# Altium schematic sheet 10 - POWER, RESET.SchDoc
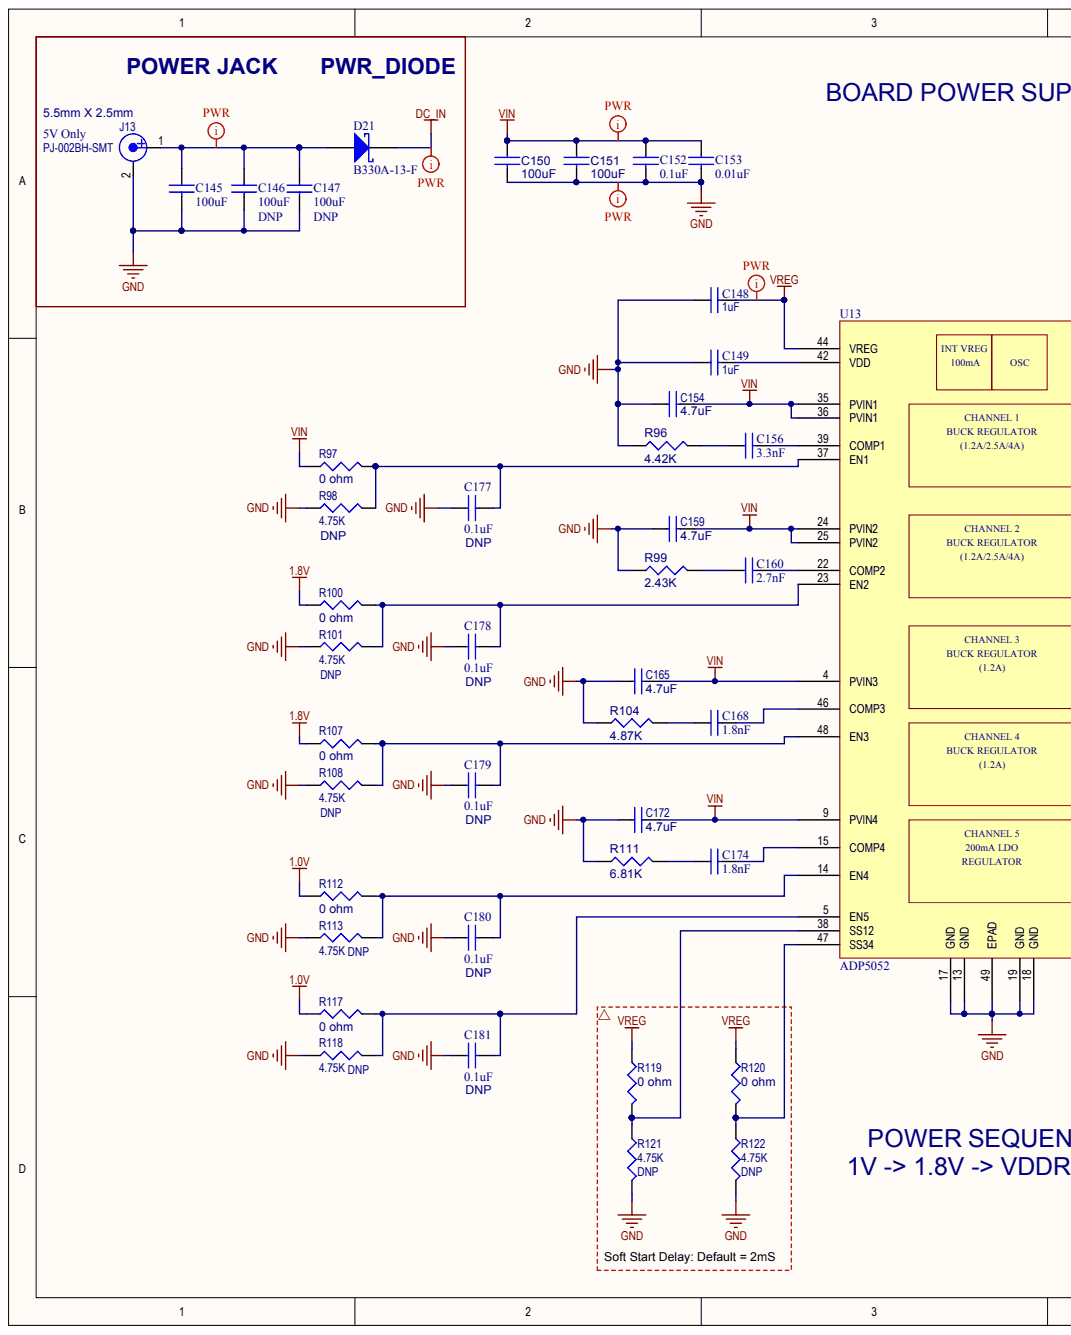
[diagram p0: 10 - POWER, RESET.SchDoc, left]
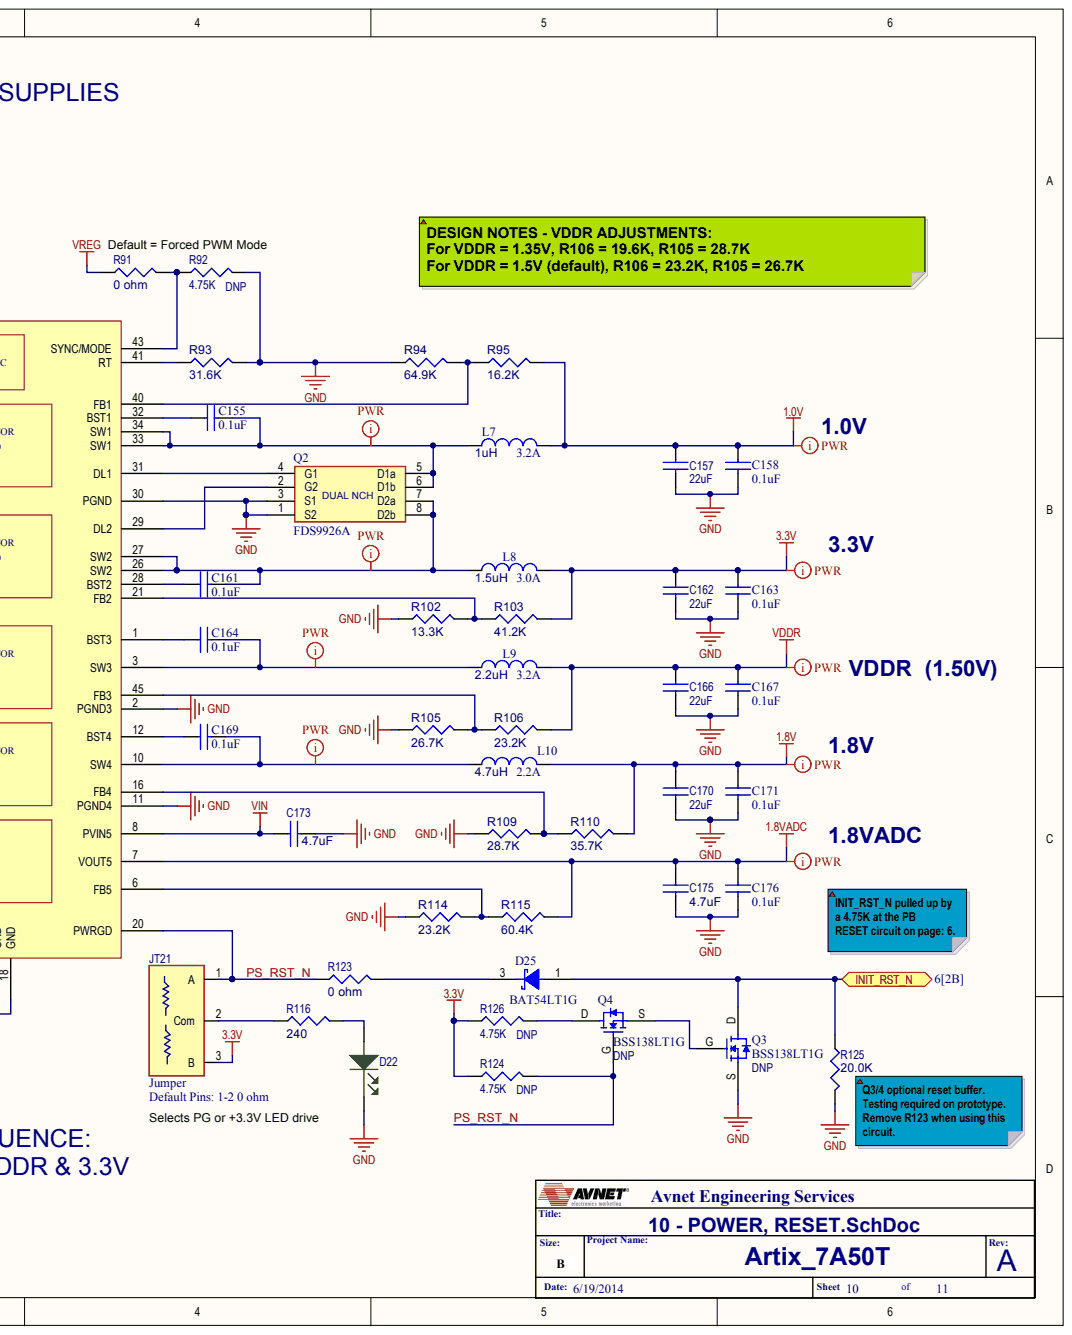
[diagram p1: 10 - POWER, RESET.SchDoc, right]

## Parts
C145  value 100uF  (hidden comment: PC074)
C146  value 100uF  (hidden comment: PC074)
C147  value 100uF  (hidden comment: PC074)
C148  value 1uF  (hidden comment: CAP_GEN_1uF_0402)
C149  value 1uF  (hidden comment: CAP_GEN_1uF_0402)
C150  100uF
C151  100uF
C152  value 0.1uF  (hidden comment: PC522)
C153  value 0.01uF  (hidden comment: PC003)
C154  value 4.7uF  (hidden comment: 4.8uF)
C155  value 0.1uF  (hidden comment: PC522)
C156  value 3.3nF
C157  value 22uF  (hidden comment: GRM219R61A226MEA0D)
C158  value 0.1uF  (hidden comment: PC522)
C159  value 4.7uF  (hidden comment: 4.8uF)
C160  value 2.7nF
C161  value 0.1uF  (hidden comment: PC522)
C162  value 22uF  (hidden comment: GRM219R61A226MEA0D)
C163  value 0.1uF  (hidden comment: PC522)
C164  value 0.1uF  (hidden comment: PC522)
C165  value 4.7uF  (hidden comment: 4.8uF)
C166  value 22uF  (hidden comment: GRM219R61A226MEA0D)
C167  value 0.1uF  (hidden comment: PC522)
C168  value 1.8nF
C169  value 0.1uF  (hidden comment: PC522)
C170  value 22uF  (hidden comment: GRM219R61A226MEA0D)
C171  value 0.1uF  (hidden comment: PC522)
C172  value 4.7uF  (hidden comment: 4.8uF)
C173  value 4.7uF  (hidden comment: 4.8uF)
C174  value 1.8nF
C175  value 4.7uF  (hidden comment: 4.8uF)
C176  value 0.1uF  (hidden comment: PC522)
C177  value 0.1uF  (hidden comment: PC522)
C178  value 0.1uF  (hidden comment: PC522)
C179  value 0.1uF  (hidden comment: PC522)
C180  value 0.1uF  (hidden comment: PC522)
C181  value 0.1uF  (hidden comment: PC522)
D21  Device: B330A-13-F  (hidden comment: DD031)
D22  DL018  (value not drawn)
D25  BAT54LT1G
J13  Device: PJ-002BH-SMT  (hidden comment: EC068)
JT21  value 0 ohm  Device: Jumper  Default Pins: 1-2 0 ohm
L7  value 1uH  I: 3.2A  (hidden comment: ME3220-102MLB)
L8  value 1.5uH  I: 3.0A  (hidden comment: ME3220-102MLB)
L9  value 2.2uH  I: 3.2A  (hidden comment: ME3220-102MLB)
L10  value 4.7uH  I: 2.2A  (hidden comment: ME3220-102MLB)
Q2  MFGPN: FDS9926A  (hidden comment: FDS9926A DUAL MOSFET)
Q3  BSS138  MFGPN: BSS138LT1G
Q4  BSS138  MFGPN: BSS138LT1G
R91  0 ohm
R92  value 4.75K  (hidden comment: 0402)
R93  value 31.6K  (hidden comment: 0402 RES, 1%, Generic)
R94  value 64.9K  (hidden comment: 0402 RES, 1%, Generic)
R95  value 16.2K  (hidden comment: 0402 RES, 1%, Generic)
R96  value 4.42K  (hidden comment: 0402 RES, 1%, Generic)
R97  0 ohm
R98  value 4.75K  (hidden comment: 0402)
R99  value 2.43K  (hidden comment: 0402 RES, 1%, Generic)
R100  0 ohm
R101  value 4.75K  (hidden comment: 0402)
R102  value 13.3K  (hidden comment: 0402 RES, 1%, Generic)
R103  value 41.2K  (hidden comment: 0402 RES, 1%, Generic)
R104  value 4.87K  (hidden comment: 0402 RES, 1%, Generic)
R105  value 26.7K  (hidden comment: 0402 RES, 1%, Generic)
R106  value 23.2K  (hidden comment: 0402 RES, 1%, Generic)
R107  0 ohm
R108  value 4.75K  (hidden comment: 0402)
R109  value 28.7K  (hidden comment: 0402 RES, 1%, Generic)
R110  value 35.7K  (hidden comment: 0402 RES, 1%, Generic)
R111  value 6.81K  (hidden comment: 0402 RES, 1%, Generic)
R112  0 ohm
R113  value 4.75K  (hidden comment: 0402)
R114  value 23.2K  (hidden comment: 0402 RES, 1%, Generic)
R115  value 60.4K  (hidden comment: 0402 RES, 1%, Generic)
R116  240
R117  0 ohm
R118  value 4.75K  (hidden comment: 0402)
R119  0 ohm
R120  0 ohm
R121  value 4.75K  (hidden comment: 0402)
R122  value 4.75K  (hidden comment: 0402)
R123  0 ohm
R124  value 4.75K  (hidden comment: 0402)
R125  20.0K
R126  value 4.75K  (hidden comment: 0402)
U13  ADP5052

## Ports
INIT_RST_N

## Nets
1.0V: C157.1 C158.1 L7.2 R95.2 R112.1 R117.1
1.8V: C170.1 C171.1 L10.2 R100.1 R107.1 R110.2
1.8VADC: C175.1 C176.1 R115.2 U13.7(VOUT5)
3.3V: C162.1 C163.1 JT21.3 L8.2 R103.2 R124.1 R126.1
DC_IN: D21.C
GND: C145.2 C146.2 C147.2 C148.1 C149.1 C150.2 C151.2 C152.2 C153.2 C154.1 C157.2 C158.2 C159.1 C162.2 C163.2 C165.1 C166.2 C167.2 C170.2 C171.2 C172.1 C173.2 C175.2 C176.2 C177.1 C178.1 C179.1 C180.1 C181.1 D22.C J13.2 Q2.1(S2) Q2.3(S1) Q3.S R92.2 R93.2 R94.1 R96.1 R98.1 R99.1 R101.1 R102.1 R104.1 R105.1 R108.1 R109.1 R111.1 R113.1 R114.1 R118.1 R121.2 R122.2 R125.2 U13.2(PGND3) U13.11(PGND4) U13.13(GND) U13.17(GND) U13.18(GND) U13.19(GND) U13.30(PGND) U13.49(EPAD)
VDDR: C166.1 C167.1 L9.2 R106.2
VIN: C150.1 C151.1 C152.1 C153.1 C154.2 C159.2 C165.2 C172.2 C173.1 R97.1 U13.4(PVIN3) U13.8(PVIN5) U13.9(PVIN4) U13.24(PVIN2) U13.25(PVIN2) U13.35(PVIN1) U13.36(PVIN1)
VREG: C148.2 R91.1 R119.1 R120.1 U13.44(VREG)
INIT_RST_N: D25.1 Q3.D R125.1 ; port INIT_RST_N
PS_RST_N: JT21.1 Q4.G R123.1 R124.2 U13.20(PWRGD)
NetC145_1: C145.1 C146.1 C147.1 D21.A J13.1
NetC149_2: C149.2 U13.42(VDD)
NetC155_1: C155.1 U13.32(BST1)
NetC155_2: C155.2 L7.1 Q2.5(D1a) Q2.6(D1b) U13.33(SW1) U13.34(SW1)
NetC156_1: C156.1 R96.2
NetC156_2: C156.2 U13.39(COMP1)
NetC160_1: C160.1 R99.2
NetC160_2: C160.2 U13.22(COMP2)
NetC161_1: C161.1 U13.28(BST2)
NetC161_2: C161.2 L8.1 Q2.7(D2a) Q2.8(D2b) U13.26(SW2) U13.27(SW2)
NetC164_1: C164.1 U13.1(BST3)
NetC164_2: C164.2 L9.1 U13.3(SW3)
NetC168_1: C168.1 R104.2
NetC168_2: C168.2 U13.46(COMP3)
NetC169_1: C169.1 U13.12(BST4)
NetC169_2: C169.2 L10.1 U13.10(SW4)
NetC174_1: C174.1 R111.2
NetC174_2: C174.2 U13.15(COMP4)
NetC177_2: C177.2 R97.2 R98.2 U13.37(EN1)
NetC178_2: C178.2 R100.2 R101.2 U13.23(EN2)
NetC179_2: C179.2 R107.2 R108.2 U13.48(EN3)
NetC180_2: C180.2 R112.2 R113.2 U13.14(EN4)
NetC181_2: C181.2 R117.2 R118.2 U13.5(EN5)
NetD22_A: D22.A R116.2
NetD25_3: D25.3 R123.2
NetJT21_2: JT21.2 R116.1
NetQ2_2: Q2.2(G2) U13.29(DL2)
NetQ2_4: Q2.4(G1) U13.31(DL1)
NetQ3_G: Q3.G Q4.S
NetQ4_D: Q4.D R126.2
NetR91_2: R91.2 R92.1 U13.43(SYNC/MODE)
NetR93_1: R93.1 U13.41(RT)
NetR94_2: R94.2 R95.1 U13.40(FB1)
NetR102_2: R102.2 R103.1 U13.21(FB2)
NetR105_2: R105.2 R106.1 U13.45(FB3)
NetR109_2: R109.2 R110.1 U13.16(FB4)
NetR114_2: R114.2 R115.1 U13.6(FB5)
NetR119_2: R119.2 R121.1 U13.38(SS12)
NetR120_2: R120.2 R122.1 U13.47(SS34)

## Library data of the parts
C145-C147 = (no symbol name): PartCode: PC; AVLNo: AVPC-0000530; PkgType: SM; Volt: 16V; Tol: 10%; Dielectric: X5R; ESR: CAP; MFG Code: TYC; MFGPN: EMK325ABJ107MM-T; AsyTxt: PC074; RoHS: Yes; Status: Preliminary; Device: CAP; ComponentLink1Description: Datasheet; ComponentLink2Description: MFR Website; ComponentLink1URL: C:\AVDBLIB\DATASHEETS\AVX_CX5R.pdf
  C146 C147: DNP: DNP
C148 C149 = (no symbol name): MFGPN: 04026D105KAT2A; PKG: 0402
C150 C151 = (no symbol name): PartCode: PC; AVLNo: AVPC-0000209; PkgType: SM; AsyTxt: PC209; Volt: 6.3V; Tol: 20%; Dielectric: X5R; MFG Code: MUR; MFGPN: GRM32EF50J107ZE20K; Power: 0; Device: CAP; Value: 100uF; RoHS: Yes; ComponentLink1Description: Datasheet; Availability: 180K-AV; Cost: .41; Size: 9.11; Status: Preliminary; Received: 0; ComponentLink1URL: C:\AVDBLIB\DATASHEETS\GRM31CR60J107ME39.pdf
C152 C155 C158 C161 C163 C164 C167 C169 C171 C176-C181 = (no symbol name): PartCode: PC; MFGPN: 02016D104KAT2A; AVLNo: AVPC-0000522; PkgType: SM; Volt: 10V; Tol: 10%; Dielectric: X5R; Device: CAP; MFG Code: AVX; Status: Preliminary; AsyTxt: PC522; RoHS: Yes; ComponentLink1Description: Datasheet; ComponentLink1URL: C:\AVDBLIB\DATASHEETS\AVX_CCOG.pdf
  C177-C181: DNP: DNP
C153 = (no symbol name): PartCode: PC; AVLNo: AVPC-0000003; PkgType: SM; Volt: 10V; Tol: 10%; Dielectric: X5R; ESR: CAP; MFG Code: AVX; MFGPN: 0201ZD103KAT2A; AsyTxt: PC003; RoHS: Yes; Status: Preliminary; Device: CAP; ComponentLink1Description: Datasheet; ComponentLink2Description: MFR Website; ComponentLink2URL: www.avx.com; ComponentLink1URL: C:\AVDBLIB\DATASHEETS\AVX_CX5R.pdf
C154 C159 C165 C172 C173 C175 = (no symbol name): PartCode: PC; AVLNo: AVPC-0000499; PkgType: SM; AsyTxt: PC499; Volt: 6.3V; Tol: 20%; Dielectric: X5R; MFG Code: AVX; MFGPN: GRM155R60J475ME87D; Power: 0; Device: CAP; RoHS: Yes; ComponentLink1Description: Datasheet; Availability: 140K-DK; Cost: .46; Size: 1.9; Status: Preliminary; Received: 0; ComponentLink1URL: C:\AVDBLIB\DATASHEETS\murata_caps_c02e.pdf
C156 = (no symbol name): Volt: 16V; Tol: 10%; Dielectric: X7R; MFG: MUR; MFGPN: GRM033R71C332KA88D; TEMP: -55+125; PKG: 0201; RoHS: Yes; Comment: =ASYTXT
C157 C162 C166 C170 = (no symbol name): PartCode: PC; AVLNo: AVPC-0000531; PkgType: SM; AsyTxt: PC112; Volt: 10V; Tol: 10%; Dielectric: X5R; ESR: CAP-P; Device: CAP; MFG Code: TAI; MFGPN: LMK212BJ226MG-T; RoHS: Yes; Status: Preliminary; ComponentLink1Description: Datasheet
C160 = (no symbol name): Volt: 16V; Tol: 10%; Dielectric: X5R; MFGPN: GRM033R61A272KA01D; MFG: MUR; PKG: 0201; TEMP: -55+85; Comment: =ASYTXT
C168 C174 = (no symbol name): Volt: 16V; Tol: 10%; Dielectric: X7R; MFG: MUR; MFGPN: GRM033R71C182KA88D; PKG: 0201; TEMP: -55+125; Comment: =ASYTXT
D21 = (no symbol name): PartCode: DD; AVLNo: AVDD-0000031; PkgType: SM; Device Type: Diode; Device Sub Type: Barrier Rectifier; Imax: 3A; Vr: 30V; MFG Code: DII; AsyTxt: DD031; Status: Preliminary; Technology: Schottky; MFGPN: B330A-13-F
D22 = (no symbol name): PartCode: DL; AVLNo: AVDL-0000018; Device: LTST-C191TGKT; Device Type: LED, GREEN; WaveLength: 525nm; Vf: 3.2V; If: 20mA; Intensity at If: 150mcd; MFG Code: LTP; Text: LED5; Color: GRN; AsyTxt: DL018; Status: Preliminary; MFGPN: LTST-C191TGKT; ComponentLink1Description: Datasheet; ComponentLink1URL: C:\AVDBLIB\DATASHEETS\LTST-C191TGKT.pdf
D25 = (no symbol name): MFG#1/Part#: OnSemi: BAT54LT1G; MFGPN: BAT54LT1G
J13 = (no symbol name): PartCode: EC; Alt1MFR: Digikey; PkgType: SM; Device Type: CONN; Sub Type: Barrel Jack; Note: 2.5A; MFG Code: CUI; MFGPN: PJ-002BH-SMT-TR; Alt1PN: CP-002APJTR-ND; Volt: 5V Only; AsyTxt: EC068; RoHS: Yes; Status: Preliminary; Technology: 2.5MMX5.5MM; ComponentLink1Description: Datasheet
JT21 = (no symbol name): PartCode: PO; PkgType: SM; Device Type: 3-pad 0R0 0402; MFG Code: DAL; MFGPN: CRCW04020R00FKED: Placed at pads 1-2; RoHS: Yes; Status: Preliminary; ComponentLink1Description: Datasheet; ComponentLink1URL: C:\AVDBLIB\DATASHEETS\Dale_CRCW.pd
L7 = (no symbol name): MFG: BRNS; MFGPN: SRU6013-1R0Y
L8 = (no symbol name): MFG: BRNS; MFGPN: SRU6013-1R5Y
L9 = (no symbol name): MFG: BRNS; MFGPN: SRU5028-2R2Y
L10 = (no symbol name): MFG: BRNS; MFGPN: SRU5028-4R7Y
Q2 = (no symbol name): MFG: FCH; PKG: SO8
Q3 Q4 = (no symbol name): PKG: SOT23-3; DNP: DNP
R91 R97 R100 R107 R112 R117 R119 R120 R123 = (no symbol name): PartCode: PR; AVLNo: AVPR-0000027; PkgType: SM; AsyTxt: PR027; Watt: 62mW; Device: RES; MFG Code: DAL; MFGPN: CRCW04020000Z0ED; Value: 0 ohm; RoHS: Yes; Status: Preliminary; ComponentLink1Description: Datasheet; ComponentLink1URL: C:\AVDBLIB\DATASHEETS\Dale_CRCW.pd
R92 R98 R101 R108 R113 R118 R121 R122 R124 R126 = (no symbol name): MFG Code: DAL; MFGPN: CRCW04024K75FKED; Note: Res Thick Film 0402 4.75K Ohm 1% 1/16W ±100ppm/°C Molded SMD SMD Paper T/R; DNP: DNP
R93 = (no symbol name): MFGPN: CRCW040231K6FKED; PKG: 0402
R94 = (no symbol name): MFGPN: CRCW040264K9FKED; PKG: 0402
R95 = (no symbol name): MFGPN: CRCW040216K2FKED; PKG: 0402
R96 = (no symbol name): MFGPN: CRCW04024K42FKED; PKG: 0402
R99 = (no symbol name): MFGPN: CRCW04022K43FKED; PKG: 0402
R102 = (no symbol name): MFGPN: CRCW040213K3FKED; PKG: 0402
R103 = (no symbol name): MFGPN: CRCW040241K2FKED; PKG: 0402
R104 = (no symbol name): MFGPN: CRCW04024K87FKED; PKG: 0402
R105 = (no symbol name): MFGPN: CRCW040226K7FKED; PKG: 0402
R106 R114 = (no symbol name): MFGPN: CRCW040223K2FKED; PKG: 0402
R109 = (no symbol name): MFGPN: CRCW040228K7FKED; PKG: 0402
R110 = (no symbol name): MFGPN: CRCW040235K7FKED; PKG: 0402
R111 = (no symbol name): MFGPN: CRCW04026K81FKED; PKG: 0402
R115 = (no symbol name): MFGPN: CRCW040260K4FKED; PKG: 0402
R116 = (no symbol name): PartCode: PR; Alt1MFR: NIC; AVLNo: AVPR-0000511; PkgType: SM; Watt: 62mW; Tol: 0.01; Device: RES; MFG Code: DAL; MFGPN: CRCW0402240RFKED; Alt1PN: NRC04F2400TRF; Power: 0; AsyTxt: PR511; Value: 240; RoHS: Yes; ComponentLink1Description: Datasheet; Availability: 1M-AV; Cost: .01; Size: 1.9; Status: Preliminary; Received: 0; ComponentLink1URL: C:\AVDBLIB\DATASHEETS\Dale_CRCW.pd
R125 = (no symbol name): PartCode: PR; AVLNo: AVPR-0000061; PkgType: SM; AsyTxt: PR061; Watt: 62mW; Tol: 0.01; Device: RES; MFG Code: DAL; MFGPN: CRCW040220K0FKED; Value: 20.0K; RoHS: Yes; Status: Preliminary; ComponentLink1Description: Datasheet; ComponentLink1URL: C:\AVDBLIB\DATASHEETS\Dale_CRCW.pd
U13 = (no symbol name): MFG: AD; MFGPN: ADP5052
